# Altium schematic sheet Project North Star - DisplayPort DSI.SchDoc
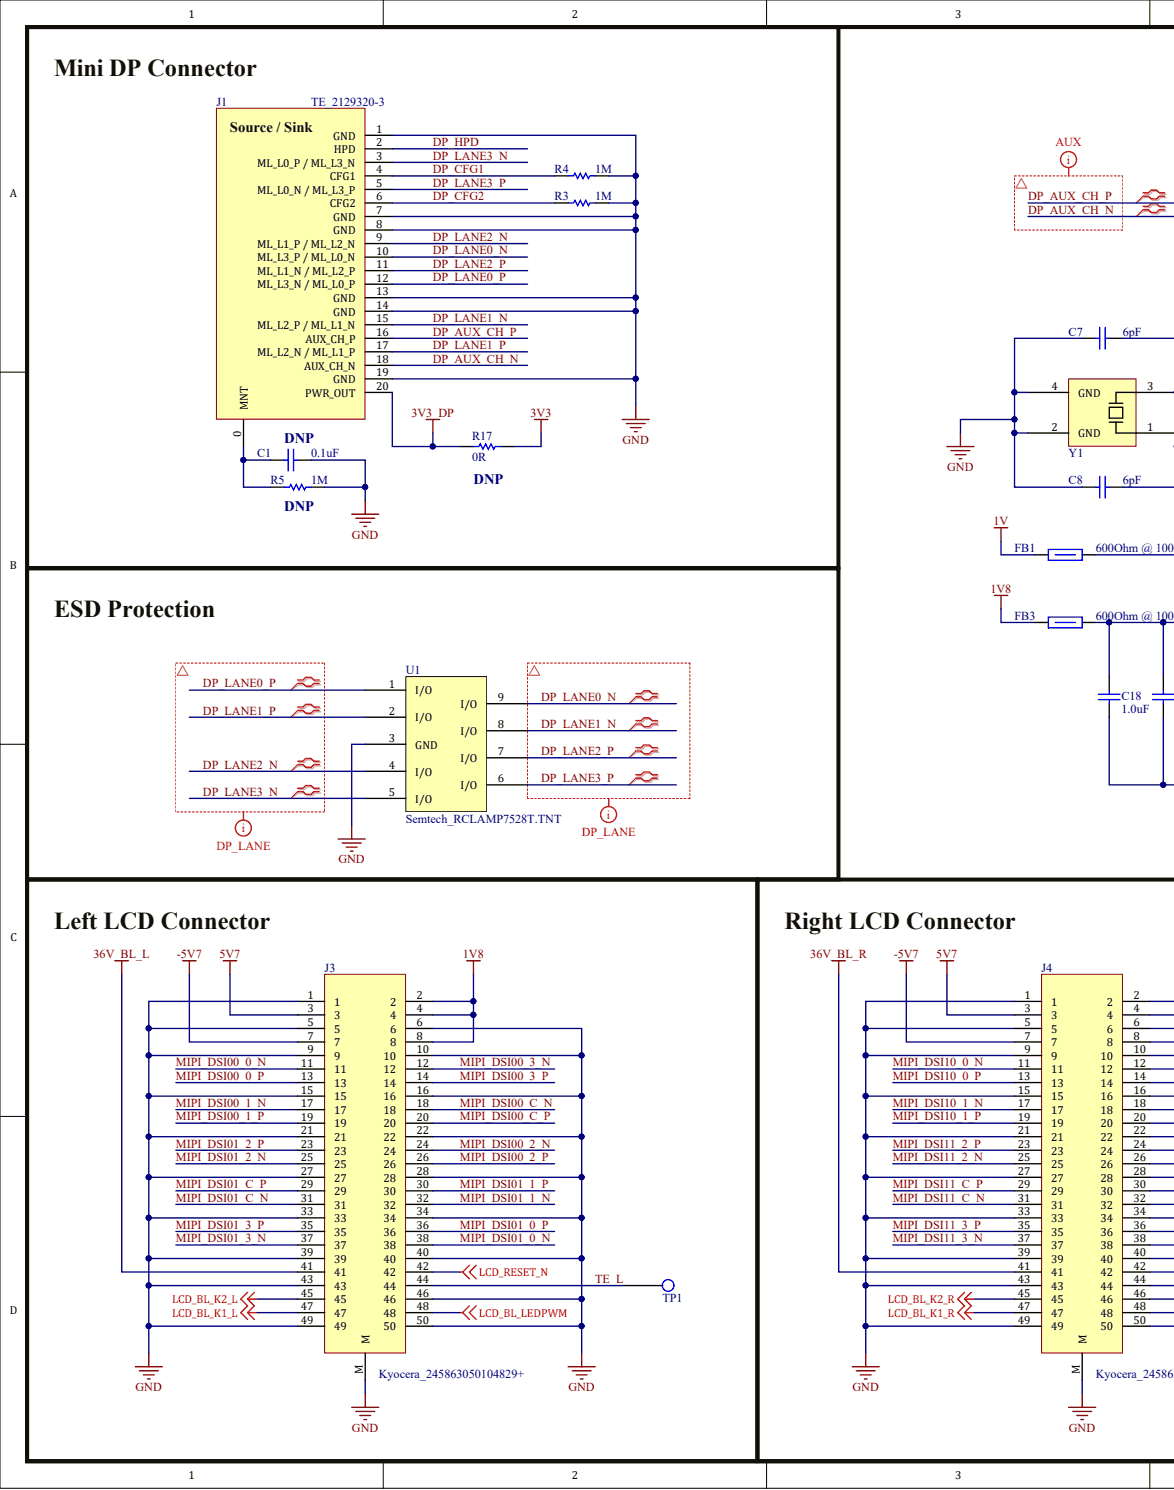
[diagram p0: Project North Star - DisplayPort DSI.SchDoc, left]
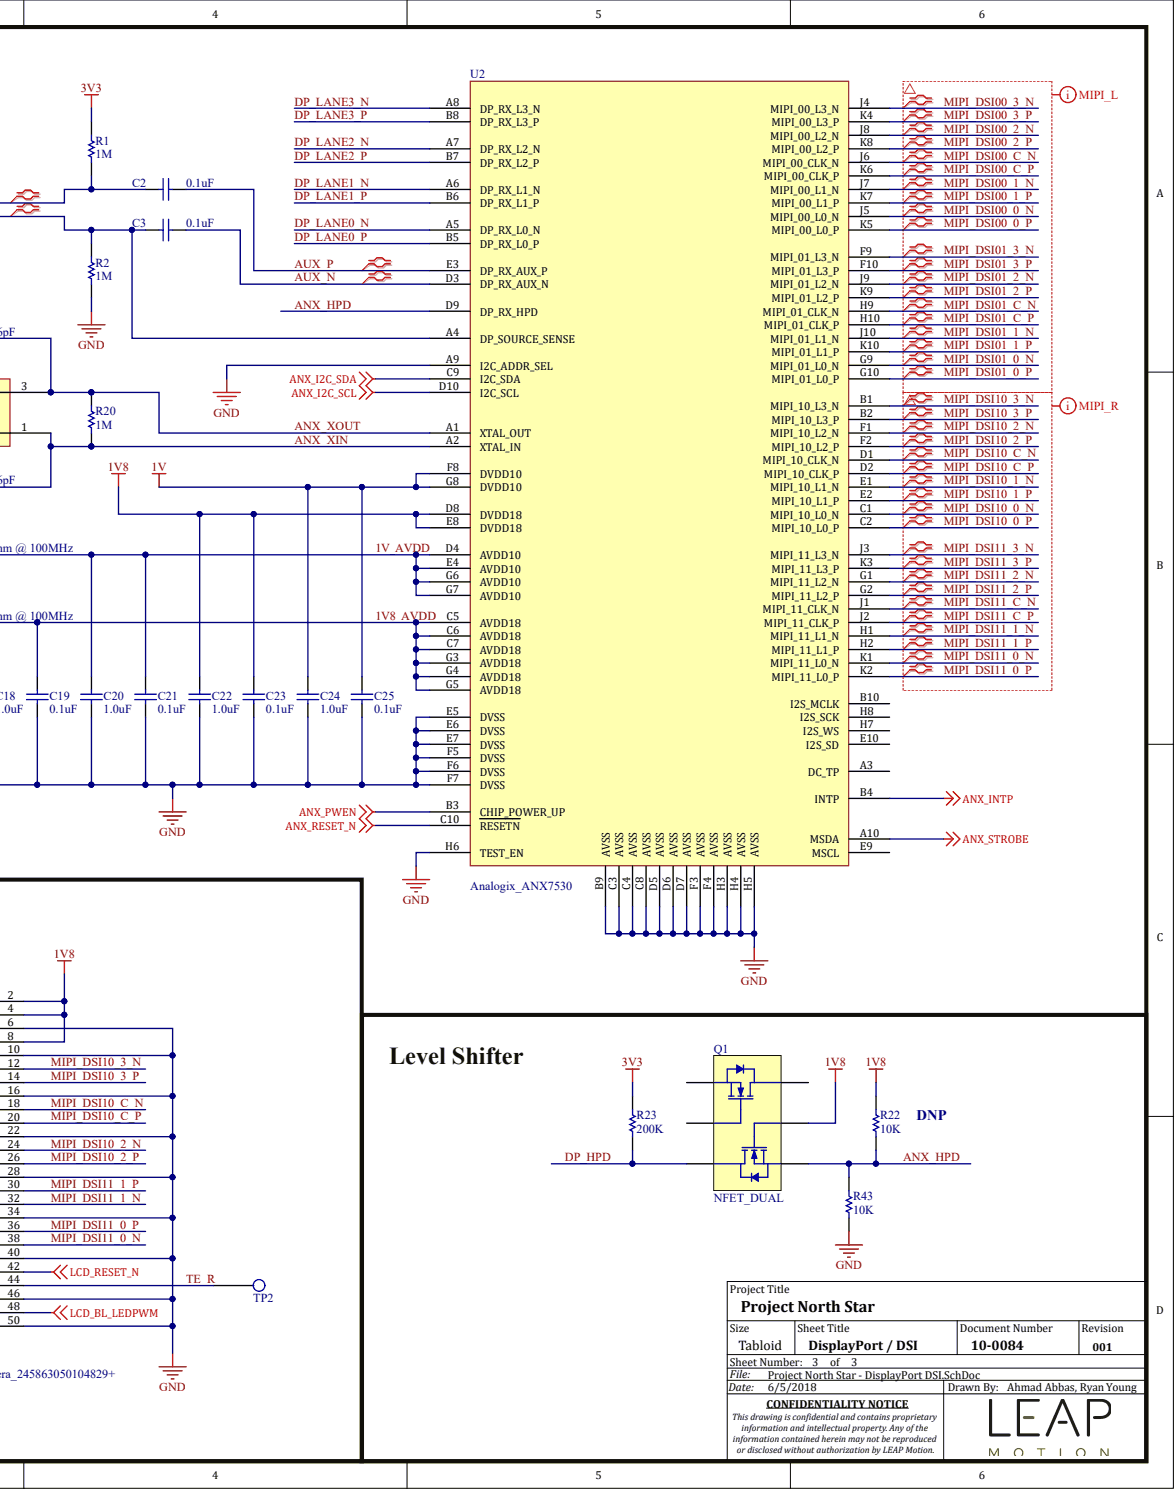
[diagram p1: Project North Star - DisplayPort DSI.SchDoc, right]

## Parts
C1  0.1uF  [CAPC0201(0603)33_N]  (no BOM)
C2  0.1uF  [CAPC0201(0603)33_N]
C3  0.1uF  [CAPC0201(0603)33_N]
C7  6pF  [CAPC0201(0603)33_N]
C8  6pF  [CAPC0201(0603)33_N]
C18  1.0uF  [CAPC0402(1005)60_L]
C19  0.1uF  [CAPC0201(0603)33_L]
C20  1.0uF  [CAPC0402(1005)60_L]
C21  0.1uF  [CAPC0201(0603)33_L]
C22  1.0uF  [CAPC0402(1005)60_L]
C23  0.1uF  [CAPC0201(0603)33_L]
C24  1.0uF  [CAPC0402(1005)60_L]
C25  0.1uF  [CAPC0201(0603)33_L]
FB1  Ferrite Bead  [INDC1608X09N]  (value not drawn)
FB3  Ferrite Bead  [INDC1608X09N]  (value not drawn)
J1  TE_2129320-3  [TE_2129320-3]
J3  Kyocera_245863050104829+  [Kyocera_245863050104829+]
J4  Kyocera_245863050104829+  [Kyocera_245863050104829+]
Q1  NFET_DUAL  [SOT-563]
R1  1M  [RESC0201(0603)_N]
R2  1M  [RESC0201(0603)_N]
R3  1M  [RESC0201(0603)_N]
R4  1M  [RESC0201(0603)_N]
R5  1M  [RESC0201(0603)_N]  (no BOM)
R17  0R  [RESC0402(1005)_N]  (no BOM)
R20  1M  [RESC0201(0603)_N]
R22  10K  [RESC0201(0603)_N]  (no BOM)
R23  200K  [RESC0201(0603)_N]
R43  10K  [RESC0201(0603)_N]
TP1  TestPoint  [TestPointRnd-1_5mm]  (value not drawn)  (no BOM)
TP2  TestPoint  [TestPointRnd-1_5mm]  (value not drawn)  (no BOM)
U1  Semtech_RCLAMP7528T.TNT  [Semtech_RCLAMP7528T.TNT]
U2  Analogix_ANX7530  [Analogix_ANX7530]
Y1  Crystal  [Abracon_ABM11]  (value not drawn)

## Nets
1V: C24.2 C25.2 FB1.1 U2.F8(DVDD10) U2.G8(DVDD10)
1V8: C22.2 C23.2 FB3.1 J3.2 J3.4 J3.8 J4.2 J4.4 J4.8 Q1.5 R22.2 U2.D8(DVDD18) U2.E8(DVDD18)
3V3: R1.2 R17.2 R23.1
3V3_DP: J1.20(PWR_OUT) R17.1
5V7: J3.3 J4.3
36V_BL_L: J3.41
36V_BL_R: J4.41
-5V7: J3.7 J4.7
ANX_I2C_SCL: U2.D10(I2C_SCL)
ANX_I2C_SDA: U2.C9(I2C_SDA)
ANX_INTP: U2.B4(INTP)
ANX_PWEN: U2.B3(CHIP_POWER_UP)
ANX_RESET_N: U2.C10(RESETN)
ANX_STROBE: U2.A10(MSDA)
GND: C1.1 C7.2 C8.2 C18.1 C19.1 C20.1 C21.1 C22.1 C23.1 C24.1 C25.1 J1.1(GND) J1.7(GND) J1.8(GND) J1.13(GND) J1.14(GND) J1.19(GND) J3.1 J3.5 J3.6 J3.9 J3.10 J3.15 J3.16 J3.21 J3.22 J3.27 J3.28 J3.33 J3.34 J3.39 J3.40 J3.43 J3.46 J3.49 J3.50 J3.M J4.1 J4.5 J4.6 J4.9 J4.10 J4.15 J4.16 J4.21 J4.22 J4.27 J4.28 J4.33 J4.34 J4.39 J4.40 J4.43 J4.46 J4.49 J4.50 J4.M R2.1 R3.1 R4.1 R5.1 R43.1 U1.3(GND) U2.A9(I2C_ADDR_SEL) U2.B9(AVSS) U2.C3(AVSS) U2.C4(AVSS) U2.C8(AVSS) U2.D5(AVSS) U2.D6(AVSS) U2.D7(AVSS) U2.E5(DVSS) U2.E6(DVSS) U2.E7(DVSS) U2.F3(AVSS) U2.F4(AVSS) U2.F5(DVSS) U2.F6(DVSS) U2.F7(DVSS) U2.H3(AVSS) U2.H4(AVSS) U2.H5(AVSS) U2.H6(TEST_EN) Y1.2(GND) Y1.4(GND)
LCD_BL_K1_L: J3.47
LCD_BL_K1_R: J4.47
LCD_BL_K2_L: J3.45
LCD_BL_K2_R: J4.45
LCD_BL_LEDPWM: J3.48 J4.48
LCD_RESET_N: J3.42 J4.42
1V8_AVDD: C18.2 C19.2 FB3.2 U2.C5(AVDD18) U2.C6(AVDD18) U2.C7(AVDD18) U2.G3(AVDD18) U2.G4(AVDD18) U2.G5(AVDD18)
1V_AVDD: C20.2 C21.2 FB1.2 U2.D4(AVDD10) U2.E4(AVDD10) U2.G6(AVDD10) U2.G7(AVDD10)
ANX_HPD: Q1.4 R22.1 R43.2 U2.D9(DP_RX_HPD)
ANX_XIN: C8.1 R20.1 U2.A2(XTAL_IN) Y1.1
ANX_XOUT: C7.1 R20.2 U2.A1(XTAL_OUT) Y1.3
AUX_N: C3.1 U2.D3(DP_RX_AUX_N)
AUX_P: C2.1 U2.E3(DP_RX_AUX_P)
DP_AUX_CH_N: C3.2 J1.18(AUX_CH_N) R2.2 U2.A4(DP_SOURCE_SENSE)
DP_AUX_CH_P: C2.2 J1.16(AUX_CH_P) R1.1
DP_CFG1: J1.4(CFG1) R4.2
DP_CFG2: J1.6(CFG2) R3.2
DP_HPD: J1.2(HPD) Q1.3 R23.2
DP_LANE0_N: J1.10(ML_L3_P_/_ML_L0_N) U1.9(I/O) U2.A5(DP_RX_L0_N)
DP_LANE0_P: J1.12(ML_L3_N_/_ML_L0_P) U1.1(I/O) U2.B5(DP_RX_L0_P)
DP_LANE1_N: J1.15(ML_L2_P_/_ML_L1_N) U1.8(I/O) U2.A6(DP_RX_L1_N)
DP_LANE1_P: J1.17(ML_L2_N_/_ML_L1_P) U1.2(I/O) U2.B6(DP_RX_L1_P)
DP_LANE2_N: J1.9(ML_L1_P_/_ML_L2_N) U1.4(I/O) U2.A7(DP_RX_L2_N)
DP_LANE2_P: J1.11(ML_L1_N_/_ML_L2_P) U1.7(I/O) U2.B7(DP_RX_L2_P)
DP_LANE3_N: J1.3(ML_L0_P_/_ML_L3_N) U1.5(I/O) U2.A8(DP_RX_L3_N)
DP_LANE3_P: J1.5(ML_L0_N_/_ML_L3_P) U1.6(I/O) U2.B8(DP_RX_L3_P)
MIPI_DSI00_0_N: J3.11 U2.J5(MIPI_00_L0_N)
MIPI_DSI00_0_P: J3.13 U2.K5(MIPI_00_L0_P)
MIPI_DSI00_1_N: J3.17 U2.J7(MIPI_00_L1_N)
MIPI_DSI00_1_P: J3.19 U2.K7(MIPI_00_L1_P)
MIPI_DSI00_2_N: J3.24 U2.J8(MIPI_00_L2_N)
MIPI_DSI00_2_P: J3.26 U2.K8(MIPI_00_L2_P)
MIPI_DSI00_3_N: J3.12 U2.J4(MIPI_00_L3_N)
MIPI_DSI00_3_P: J3.14 U2.K4(MIPI_00_L3_P)
MIPI_DSI00_C_N: J3.18 U2.J6(MIPI_00_CLK_N)
MIPI_DSI00_C_P: J3.20 U2.K6(MIPI_00_CLK_P)
MIPI_DSI01_0_N: J3.38 U2.G9(MIPI_01_L0_N)
MIPI_DSI01_0_P: J3.36 U2.G10(MIPI_01_L0_P)
MIPI_DSI01_1_N: J3.32 U2.J10(MIPI_01_L1_N)
MIPI_DSI01_1_P: J3.30 U2.K10(MIPI_01_L1_P)
MIPI_DSI01_2_N: J3.25 U2.J9(MIPI_01_L2_N)
MIPI_DSI01_2_P: J3.23 U2.K9(MIPI_01_L2_P)
MIPI_DSI01_3_N: J3.37 U2.F9(MIPI_01_L3_N)
MIPI_DSI01_3_P: J3.35 U2.F10(MIPI_01_L3_P)
MIPI_DSI01_C_N: J3.31 U2.H9(MIPI_01_CLK_N)
MIPI_DSI01_C_P: J3.29 U2.H10(MIPI_01_CLK_P)
MIPI_DSI10_0_N: J4.11 U2.C1(MIPI_10_L0_N)
MIPI_DSI10_0_P: J4.13 U2.C2(MIPI_10_L0_P)
MIPI_DSI10_1_N: J4.17 U2.E1(MIPI_10_L1_N)
MIPI_DSI10_1_P: J4.19 U2.E2(MIPI_10_L1_P)
MIPI_DSI10_2_N: J4.24 U2.F1(MIPI_10_L2_N)
MIPI_DSI10_2_P: J4.26 U2.F2(MIPI_10_L2_P)
MIPI_DSI10_3_N: J4.12 U2.B1(MIPI_10_L3_N)
MIPI_DSI10_3_P: J4.14 U2.B2(MIPI_10_L3_P)
MIPI_DSI10_C_N: J4.18 U2.D1(MIPI_10_CLK_N)
MIPI_DSI10_C_P: J4.20 U2.D2(MIPI_10_CLK_P)
MIPI_DSI11_0_N: J4.38 U2.K1(MIPI_11_L0_N)
MIPI_DSI11_0_P: J4.36 U2.K2(MIPI_11_L0_P)
MIPI_DSI11_1_N: J4.32 U2.H1(MIPI_11_L1_N)
MIPI_DSI11_1_P: J4.30 U2.H2(MIPI_11_L1_P)
MIPI_DSI11_2_N: J4.25 U2.G1(MIPI_11_L2_N)
MIPI_DSI11_2_P: J4.23 U2.G2(MIPI_11_L2_P)
MIPI_DSI11_3_N: J4.37 U2.J3(MIPI_11_L3_N)
MIPI_DSI11_3_P: J4.35 U2.K3(MIPI_11_L3_P)
MIPI_DSI11_C_N: J4.31 U2.J1(MIPI_11_CLK_N)
MIPI_DSI11_C_P: J4.29 U2.J2(MIPI_11_CLK_P)
TE_L: J3.44 TP1.0
TE_R: J4.44 TP2.0
NetC1_2: C1.2 J1.0(MNT) R5.2
N00082:
N00083:
N00084:
N00085:
N00086:

Not connected: Q1 1 2 6; U2 A3(DC_TP) B10(I2S_MCLK) E9(MSCL) E10(I2S_SD) H7(I2S_WS) H8(I2S_SCK)

## Library data of the parts
C1-C3 C19 C21 C23 C25 = CAP_0060: Capacitor, 0.1uF, 10V, 10%, 0201, Ceramic, X5R; Manufacturer: Murata; Manufacturer P/N: GRM033R61A104KE15D; Database Table Name: Capacitors; Polarity: No; Temp Range: -55C - 85C; Datasheet: http://www.mouser.com/ds/2/281/c02e-2905.pdf; Date: 6/29/2016; Dielectric: X5R; Display: 0.1uF, 10V; Material: Ceramic; Max Height: 0.15mm; P/N: CAP_0060; Package: 0201; RoHS: True; Supplier: Mouser; Supplier P/N: 581-81-GRM033R61A104KE5D; Supplier Price: 0.1; Tolerance: 10%; Value: 0.1uF; Voltage Rating: 10V; Author: AA; Library Name: LEAPdb.DbLib
  C2 C3 C19 C21 C23 C25: Component Kind: Standard
C7 C8 = CAP_0064: Capacitor, 6pF, 50V, 0.1pF, 0201, Ceramic, C0G(NP0); Manufacturer: Murata; Manufacturer P/N: GRM0335C1H6R0BA01D; Database Table Name: Capacitors; Polarity: No; Temp Range: -55C - 125C; Component Kind: Standard; Datasheet: http://search.murata.co.jp/Ceramy/image/img/A01X/G101/ENG/GRM0335C1H6R0BA01-01.pdf; Date: 7/19/2017; Dielectric: C0G(NP0); Display: 6pF, 50V; Material: Ceramic; Max Height: 0.15mm; P/N: CAP_0064; Package: 0201; RoHS: True; Supplier: Digikey; Supplier P/N: 490-11339-1-ND; Supplier Price: 0.1; Tolerance: 0.1pF; Value: 6pF; Voltage Rating: 50V; Author: RY; Library Name: LEAPdb.DbLib
C18 C20 C22 C24 = CAP_0023: Capacitor, 1.0uF, 10V, 10%, 0402, Ceramic, X5R; Manufacturer: TDK; Manufacturer P/N: C1005X5R1A105K050BB; Database Table Name: Capacitors; Polarity: No; Temp Range: -55C - 85C; Component Kind: Standard; Datasheet: http://product.tdk.com/capacitor/mlcc/en/documents/mlcc_commercial_general_en.pdf; Date: 8/28/2013; Dielectric: X5R; Display: 1.0uF, 10V; Material: Ceramic; Max Height: 0.55mm; P/N: CAP_0023; Package: 0402; RoHS: True; Supplier: Digikey; Supplier P/N: 445-4114-6-ND; Supplier Price: 0.1; Tolerance: 10%; Value: 1.0uF; Voltage Rating: 10V; Author: NR; Library Name: LEAPdb.DbLib
FB1 FB3 = FB_0001: FERRITE CHIP BEAD 600 OHM SMD; Manufacturer: TDK; Manufacturer P/N: MPZ1608S601A; Current Rating: 1A; Database Table Name: Ferrite Beads; DCR: 150mOhm; Impedance @ Freq: 600Ohm @ 100MHz; Component Kind: Standard; Datasheet: http://www.tdk.co.jp/tefe02/e9413_mpz.pdf; Date: 2/27/2013; Operating Temp Range: -55C - 125C; P/N: FB_0001; Package: 0603; Supplier: Digikey; Supplier P/N: 445-2205-1-ND; Supplier Price: 0.09; Author: NR; Library Name: LEAPdb.DbLib
J1 = CONN_0094: Connector, TE Connectivity, Receptacle, 20POS, 0.9mm; Database Table Name: Connectors; Display Value: TE Connectivity 2129320-3; Mounting Height: 4.17mm; Rows: 2; Temp Range: -40 - 85C; Component Kind: Standard; Datasheet: http://www.te.com/commerce/DocumentDelivery/DDEController?Action=srchrtrv&DocNm=2129320&DocType=Customer+Drawing&DocLang=English; Date: 7/17/2017; Direction: Receptacle; Manufacturer: TE Connectivity; Manufacturer P/N: 2129320-3; Mounting Type: SMD; P/N: CONN_0094; Pins: 20; Pitch: 0.9mm; RoHS: True; Supplier: Digikey; Supplier P/N: A120537CT-ND; Supplier Price: 2.36; Type: Mini DisplayPort; Author: RY; Library Name: LEAPdb.DbLib
J3 J4 = CONN_0107: Connector, Kyocera, Receptacle, 50POS, 0.35mm; Database Table Name: Connectors; Display Value: Kyocera 245863050104829+; Mounting Height: 0.8mm; Rows: 2; Temp Range: -55 - 85C; Component Kind: Standard; Datasheet: https://global.kyocera.com/prdct/electro/product/pdf/5863.pdf; Date: 4/23/2018; Direction: Receptacle; Manufacturer: Kyocera; Manufacturer P/N: 245863050104829+; Mounting Type: SMD; P/N: CONN_0107; Pins: 50; Pitch: 0.35mm; RoHS: True; Supplier: Kyocera; Supplier P/N: 245863050104829+; Type: Board to Board; Author: RY; Library Name: LEAPdb.DbLib
Q1 = NFET_0009: Transistor, NFET_DUAL, 2.2Ohm, 200mA, 120mW, 	SOT-563, -55C - 150C; Manufacturer: Rohm Semiconductor; Manufacturer P/N: EM6K34T2CR; Database Table Name: FETs; Display: NFET_DUAL, 	SOT-563; Component Kind: Standard; Datasheet: http://rohmfs.rohm.com/en/products/databook/datasheet/discrete/transistor/mosfet/em6k34.pdf; Date: 7/19/2017; Drain Current: 200mA; Max Height: 0.5mm; P/N: NFET_0009; Package: SOT-563; Power Rating: 120mW; Rds: 2.2Ohm; RoHS: True; Supplier: Digikey; Supplier P/N: EM6K34T2CRCT-ND; Supplier Price: 0.45; Temp Range: -55C - 150C; Author: RY; Library Name: LEAPdb.DbLib
R1-R5 R20 = RES_0178: Resistor, 1M, 1/20W, 1%, 0201; Manufacturer: Panasonic Electronic Components; Manufacturer P/N: ERJ-1GEF1004C; Database Table Name: Resistors; Display Value: 1M, 0201; Temp Range: -55C - 125C; Datasheet: https://media.digikey.com/pdf/Data%20Sheets/Panasonic%20Resistors%20Thermistors%20PDFs/ERJ_G,R,E_Rev08.pdf; Date: 7/20/2017; Max Height: 0.26mm; P/N: RES_0178; Package: 0201; Power Rating: 1/20W; RoHS: True; Supplier: Digikey; Supplier P/N: P1.00MABCT-ND; Supplier Price: 0.1; Tolerance: 1%; Value: 1M; Author: RY; Library Name: LEAPdb.DbLib
  R1-R4 R20: Component Kind: Standard
R17 = RES_0006: Resistor, 0R, 1/10W, Jumper, 0402; Manufacturer: Panasonic Electronic Components; Manufacturer P/N: ERJ-2GE0R00X; Database Table Name: Resistors; Display Value: 0R, 0402; Temp Range: -55C - 155C; Datasheet: http://industrial.panasonic.com/www-data/pdf/AOA0000/AOA0000CE1.pdf; Date: 2/21/2013; Max Height: 0.40mm; P/N: RES_0006; Package: 0402; Power Rating: 1/10W; RoHS: True; Supplier: Digikey; Supplier P/N: P0.0JCT-ND; Supplier Price: 0.05; Tolerance: Jumper; Value: 0R; Author: NR; Library Name: LEAPdb.DbLib
R22 R43 = RES_0014: Resistor, 10K, 1/20W, 5%, 0201; Manufacturer: Panasonic Electronic Components; Manufacturer P/N: ERJ-1GEJ103C; Database Table Name: Resistors; Display Value: 10K, 0201; Temp Range: -55C - 155C; Datasheet: http://industrial.panasonic.com/www-data/pdf/AOA0000/AOA0000CE2.pdf#http://industrial.panasonic.com/www-data/pdf/AOA0000/AOA0000CE2.pdf; Date: 2/25/2013; Max Height: 0.26mm; P/N: RES_0014; Package: 0201; Power Rating: 1/20W; RoHS: True; Supplier: Digikey; Supplier P/N: P10KAGCT-ND; Supplier Price: 0.1; Tolerance: 5%; Value: 10K; Author: NR; Library Name: LEAPdb.DbLib
  R43: Component Kind: Standard
R23 = RES_0034: Resistor, 200K, 1/20W, 1%, 0201; Manufacturer: Panasonic Electronic Components; Manufacturer P/N: ERJ-1GEF2003C; Database Table Name: Resistors; Display Value: 200K, 0201; Temp Range: -55C - 125C; Component Kind: Standard; Date: 7/23/2013; Max Height: 0.23mm; P/N: RES_0034; Package: 0201; Power Rating: 1/20W; RoHS: True; Supplier: Digikey; Supplier P/N: P200KABCT-ND; Supplier Price: 0.11; Tolerance: 1%; Value: 200K; Author: JDS; Library Name: LEAPdb.DbLib
TP1 TP2 = MISC_0052: Misc, TestPoint; Class: Misc; Database Table Name: Misc; Date: 6/29/2017; Display: TestPoint; Manufacturer: TestPoint; Manufacturer P/N: TestPointRnd-1_5mm; P/N: MISC_0052; RoHS: False; Author: RY; Library Name: LEAPdb.DbLib
U1 = IC_0201: TVS DIODE 5VWM 15VC SLP2611N9T; Database Table Name: ICs; Component Kind: Standard; Datasheet: http://www.semtech.com/images/datasheet/rclamp7528t.pdf; Date: 7/25/2017; Manufacturer: Semtech; Manufacturer P/N: RCLAMP7528T.TNT; Operating Temp Range: -55C - 125C; P/N: IC_0201; Package: XFDFN-9; Supplier: Digikey; Supplier P/N: RCLAMP7528T.TNTCT-ND; Supplier Price: 1.37; Author: RY; Library Name: LEAPdb.DbLib
U2 = IC_0196: DisplayPort 1.4-to-Dual MIPI Output Converter; Database Table Name: ICs; Component Kind: Standard; Date: 7/13/2017; Manufacturer: Analogix; Manufacturer P/N: ANX7530; Operating Temp Range: 0C - 70C; P/N: IC_0196; Package: VFBGA-100; Author: RY; Library Name: LEAPdb.DbLib
Y1 = XTAL_0025: Crystal, 24MHz, 6pF, 4-SMD (2x1.6); Manufacturer: Abracon; Manufacturer P/N: XRCGB24M000F2P0R0; Database Table Name: Crystals; Tolerance: 20ppm; Component Kind: Standard; Datasheet: http://www.mouser.com/ds/2/281/p79e-522722.pdf; Date: 9/14/2016; Frequency: 24MHz; Load Capacitance: 6pF; Operating Temp Range: -40C - 85C; P/N: XTAL_0025; Package: 4-SMD (2x1.6); Supplier: Mouser; Supplier P/N: 81-XRCGB24M000F2P0R0; Supplier Price: 0.38; Type: Crystal; Author: AA; Library Name: LEAPdb.DbLib
